# Altium schematic sheet P01 DP TO  HDMI.SchDoc("P01 DP TO  HDMI")
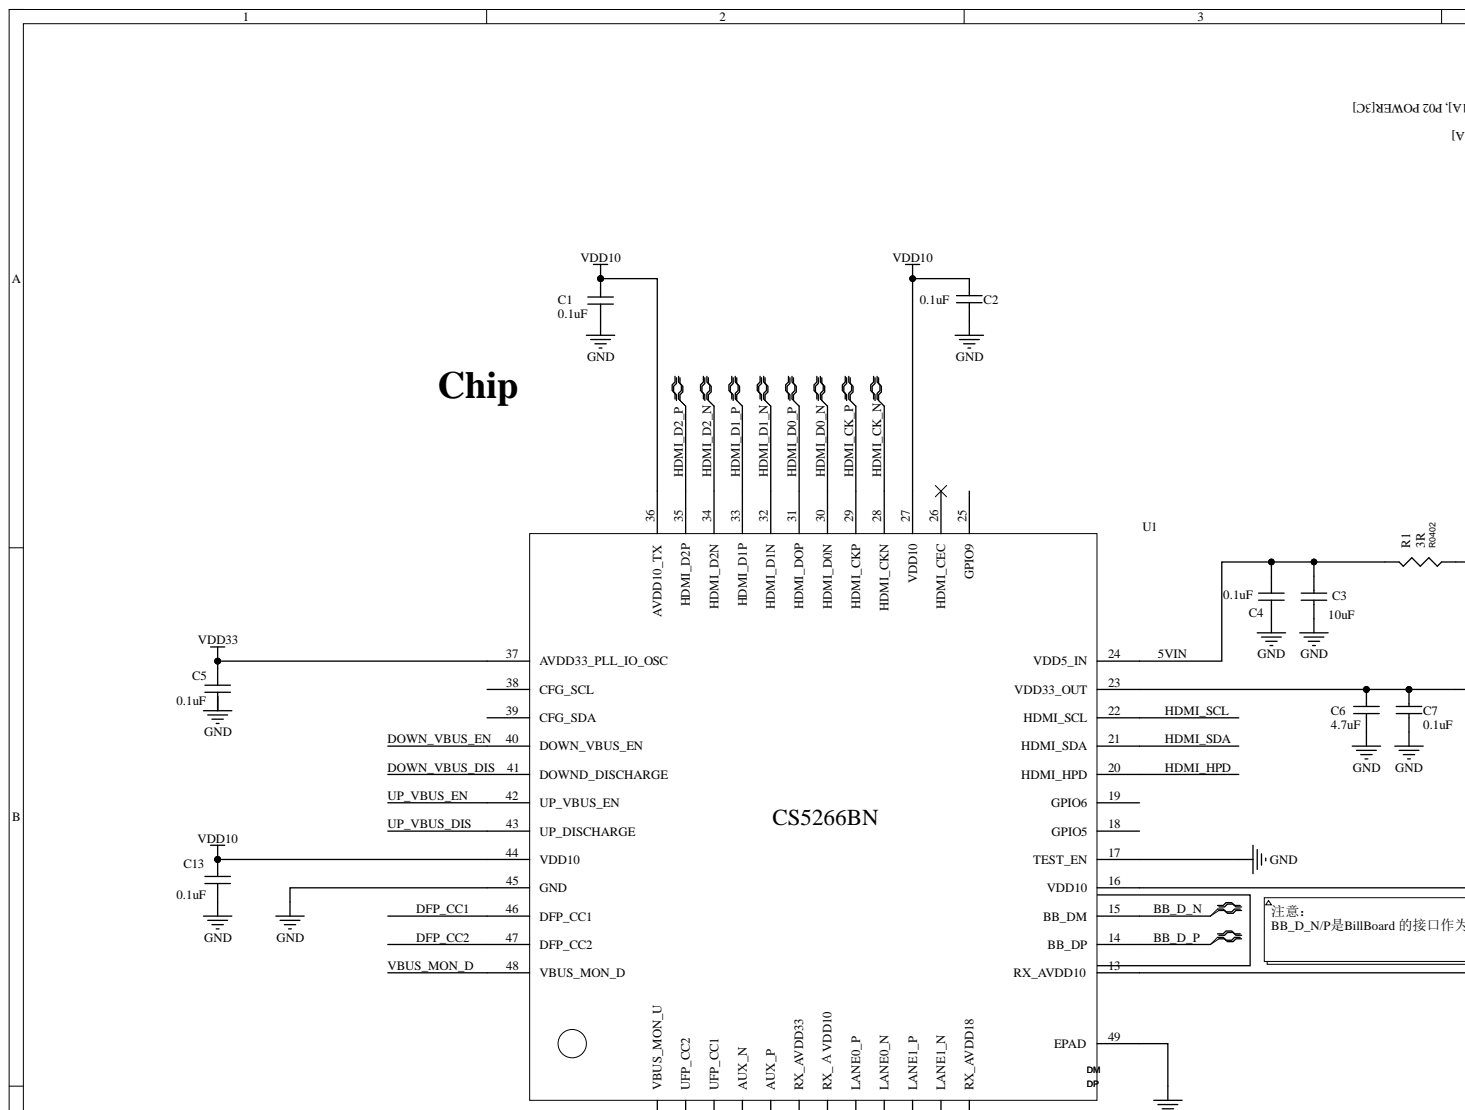
[diagram p0: P01 DP TO  HDMI.SchDoc, top left]
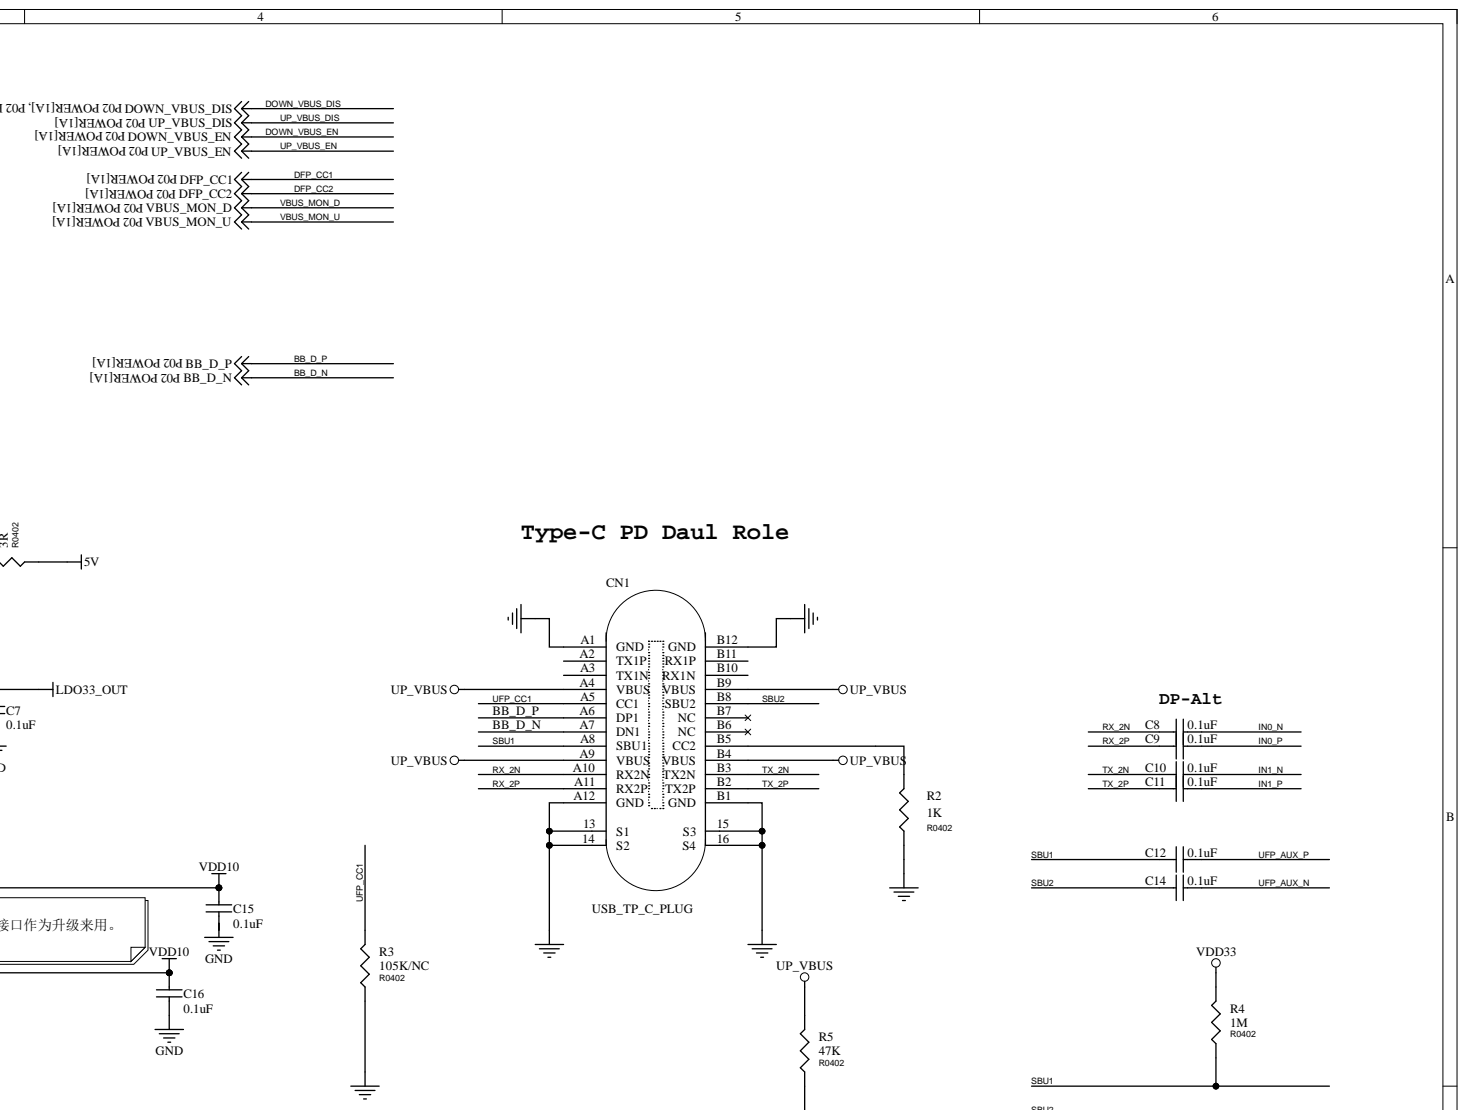
[diagram p1: P01 DP TO  HDMI.SchDoc, top right]
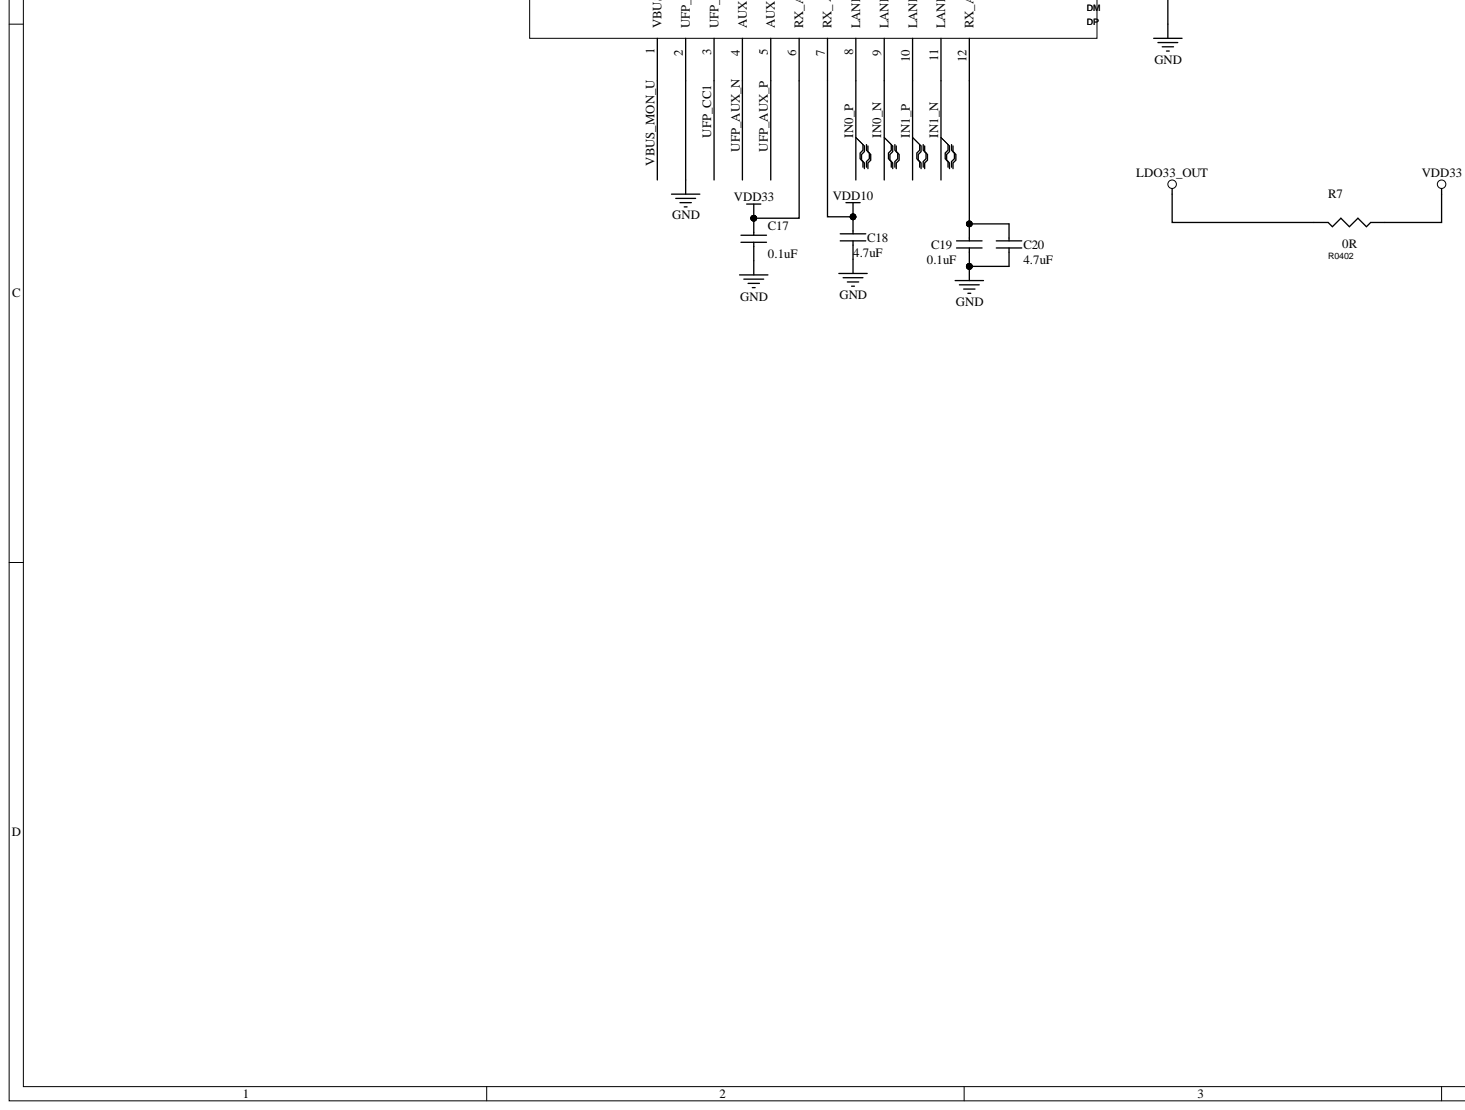
[diagram p2: P01 DP TO  HDMI.SchDoc, bottom left]
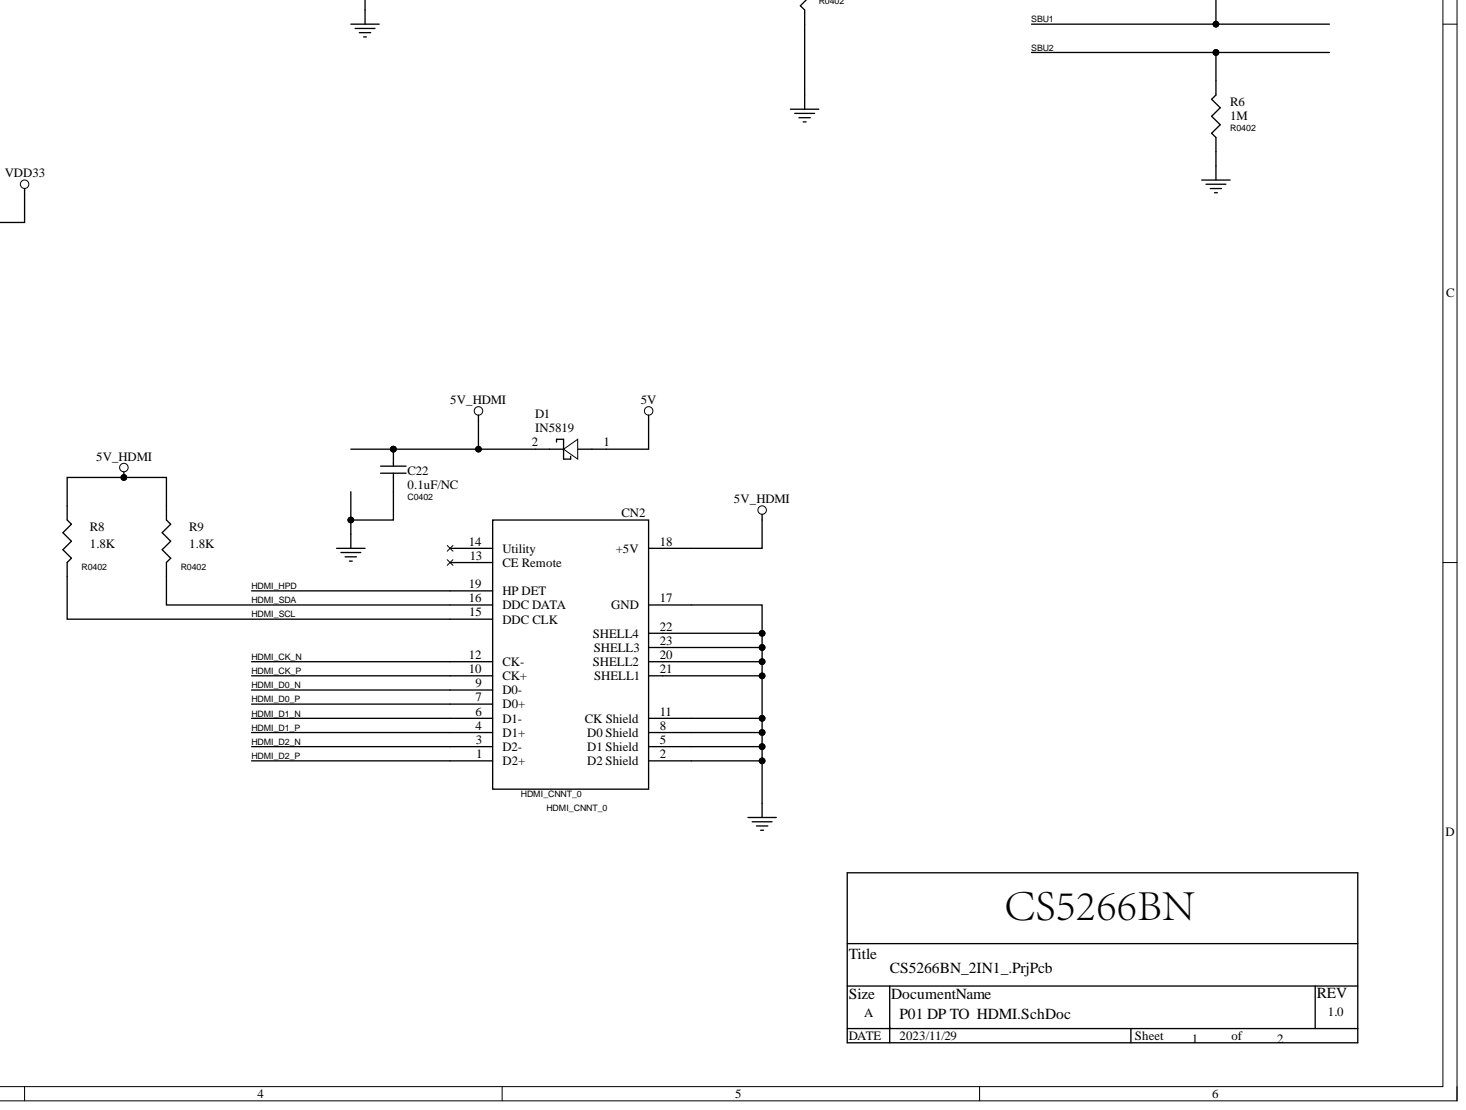
[diagram p3: P01 DP TO  HDMI.SchDoc, bottom right]

## Parts
C1  0.1uF  [C0402]
C2  0.1uF  [C0402]
C3  10uF  [C0603]
C4  0.1uF  [C0402]
C5  0.1uF  [C0402]
C6  4.7uF  [C0603]
C7  0.1uF  [C0402]
C8  0.1uF  [C0402]
C9  0.1uF  [C0402]
C10  0.1uF  [C0402]
C11  0.1uF  [C0402]
C12  0.1uF  [C0402]
C13  0.1uF  [C0402]
C14  0.1uF  [C0402]
C15  0.1uF  [C0402]
C16  0.1uF  [C0402]
C17  0.1uF  [C0402]
C18  4.7uF  [C0603]
C19  0.1uF  [C0402]
C20  4.7uF  [C0603]
C22  0.1uF/NC  [C0402]
CN1  USB_TP_C_PLUG  [CXJo]
CN2  HDMI_CNNT_0  [HDMI-SMD_HDMI-215]
D1  IN5819  [SOD323]
R1  3R  PCB Footprint: R0402  [R0603]
R2  1K  [R0402]
R3  105K/NC  PCB Footprint: R0402  [R0603]
R4  1M  PCB Footprint: R0402  [R0603]
R5  47K  PCB Footprint: R0402  [R0603]
R6  1M  PCB Footprint: R0402  [R0603]
R7  0R  PCB Footprint: R0402  [R0603]
R8  1.8K  PCB Footprint: R0402  [R0603]
R9  1.8K  PCB Footprint: R0402  [R0603]
U1  CS5266BN  [QFN48-6*6]

## Nets
5V: D1.1 R1.2
5V_HDMI: C22.1 CN2.18 D1.2 R8.2 R9.2
GND: C1.1 C2.2 C3.2 C4.2 C5.2 C6.2 C7.2 C13.2 C15.2 C16.2 C17.2 C18.2 C19.2 C20.2 C22.2 CN1.13 CN1.14 CN1.15 CN1.16 CN1.A1 CN1.A12 CN1.B1 CN1.B12 CN2.2 CN2.5 CN2.8 CN2.11 CN2.17 CN2.20 CN2.21 CN2.22 CN2.23 R2.1 R3.2 R5.2 R6.2 U1.2 U1.17 U1.45 U1.49
LDO33_OUT: C6.1 C7.1 R7.1 U1.23
UP_VBUS: CN1.A4 CN1.A9 CN1.B4 CN1.B9 R5.1
VDD10: C1.2 C2.1 C13.1 C15.1 C16.1 C18.1 U1.7 U1.13 U1.16 U1.27 U1.36 U1.44
VDD33: C5.1 C17.1 R4.1 R7.2 U1.6 U1.37
5VIN: C3.1 C4.1 R1.1 U1.24
BB_D_N: CN1.A7 U1.15
BB_D_P: CN1.A6 U1.14
DFP_CC1: U1.46
DFP_CC2: U1.47
DOWN_VBUS_DIS: U1.41
DOWN_VBUS_EN: U1.40
HDMI_CK_N: CN2.12 U1.28
HDMI_CK_P: CN2.10 U1.29
HDMI_D0_N: CN2.9 U1.30
HDMI_D0_P: CN2.7 U1.31
HDMI_D1_N: CN2.6 U1.32
HDMI_D1_P: CN2.4 U1.33
HDMI_D2_N: CN2.3 U1.34
HDMI_D2_P: CN2.1 U1.35
HDMI_HPD: CN2.19 U1.20
HDMI_SCL: CN2.15 R8.1 U1.22
HDMI_SDA: CN2.16 R9.1 U1.21
IN0_N: C8.2 U1.9
IN0_P: C9.2 U1.8
IN1_N: C10.2 U1.11
IN1_P: C11.2 U1.10
RX_2N: C8.1 CN1.A10
RX_2P: C9.1 CN1.A11
SBU1: C12.1 CN1.A8 R4.2
SBU2: C14.1 CN1.B8 R6.1
TX_2N: C10.1 CN1.B3
TX_2P: C11.1 CN1.B2
UFP_AUX_N: C14.2 U1.4
UFP_AUX_P: C12.2 U1.5
UFP_CC1: CN1.A5 R3.1 U1.3
UP_VBUS_DIS: U1.43
UP_VBUS_EN: U1.42
VBUS_MON_D: U1.48
VBUS_MON_U: U1.1
NetC19_1: C19.1 C20.1 U1.12
NetCN1_B5: CN1.B5 R2.2
DM:
DP:

Not connected: CN1 A2 A3 B6 B7 B10 B11; CN2 13 14; U1 18 19 25 26 38 39

## Library data of the parts
C1-C7 C13 C15-C20 = CAP NP: Component Kind: Standard
C8-C12 C14 = CAP NP_1: Component Kind: Standard; PCB Footprint: C0402
C22 = CAP 0603_5: Component Kind: Standard; PCB Footprint: C0402
CN1 = USB_TP_C_Receptacle_0: PCB Footprint: TYPEC_18_16; Component Kind: Standard
CN2 = HDMI_CNNT_5: HDMI_SMT_1.2A; MFG: JAE; P/N: 11.190M4G007; PN0: 11.190M4G002; PN0D: CNNT HDMI M 19P 0.5mm SMT/RT 47151-1101; "MOLEX; Component Kind: Standard; PCB Footprint: HDMI_CNNT_0; Vendor: JAE
D1 = DIODE SCHOTTKY_2: Location: T; P/N: 09.PMEG4G001; Component Kind: Standard; PCB Footprint: SOD323
R1 R3-R9 = R-0603_12: Component Kind: Standard
R2 = R: Component Kind: Standard; PCB Footprint: R0402
U1 = CS5266BN: Component Kind: Standard; Library Name: CS5266BN_EVB.SCHLIB
